# Altium schematic sheet KEA128.SchDoc(KEA128)
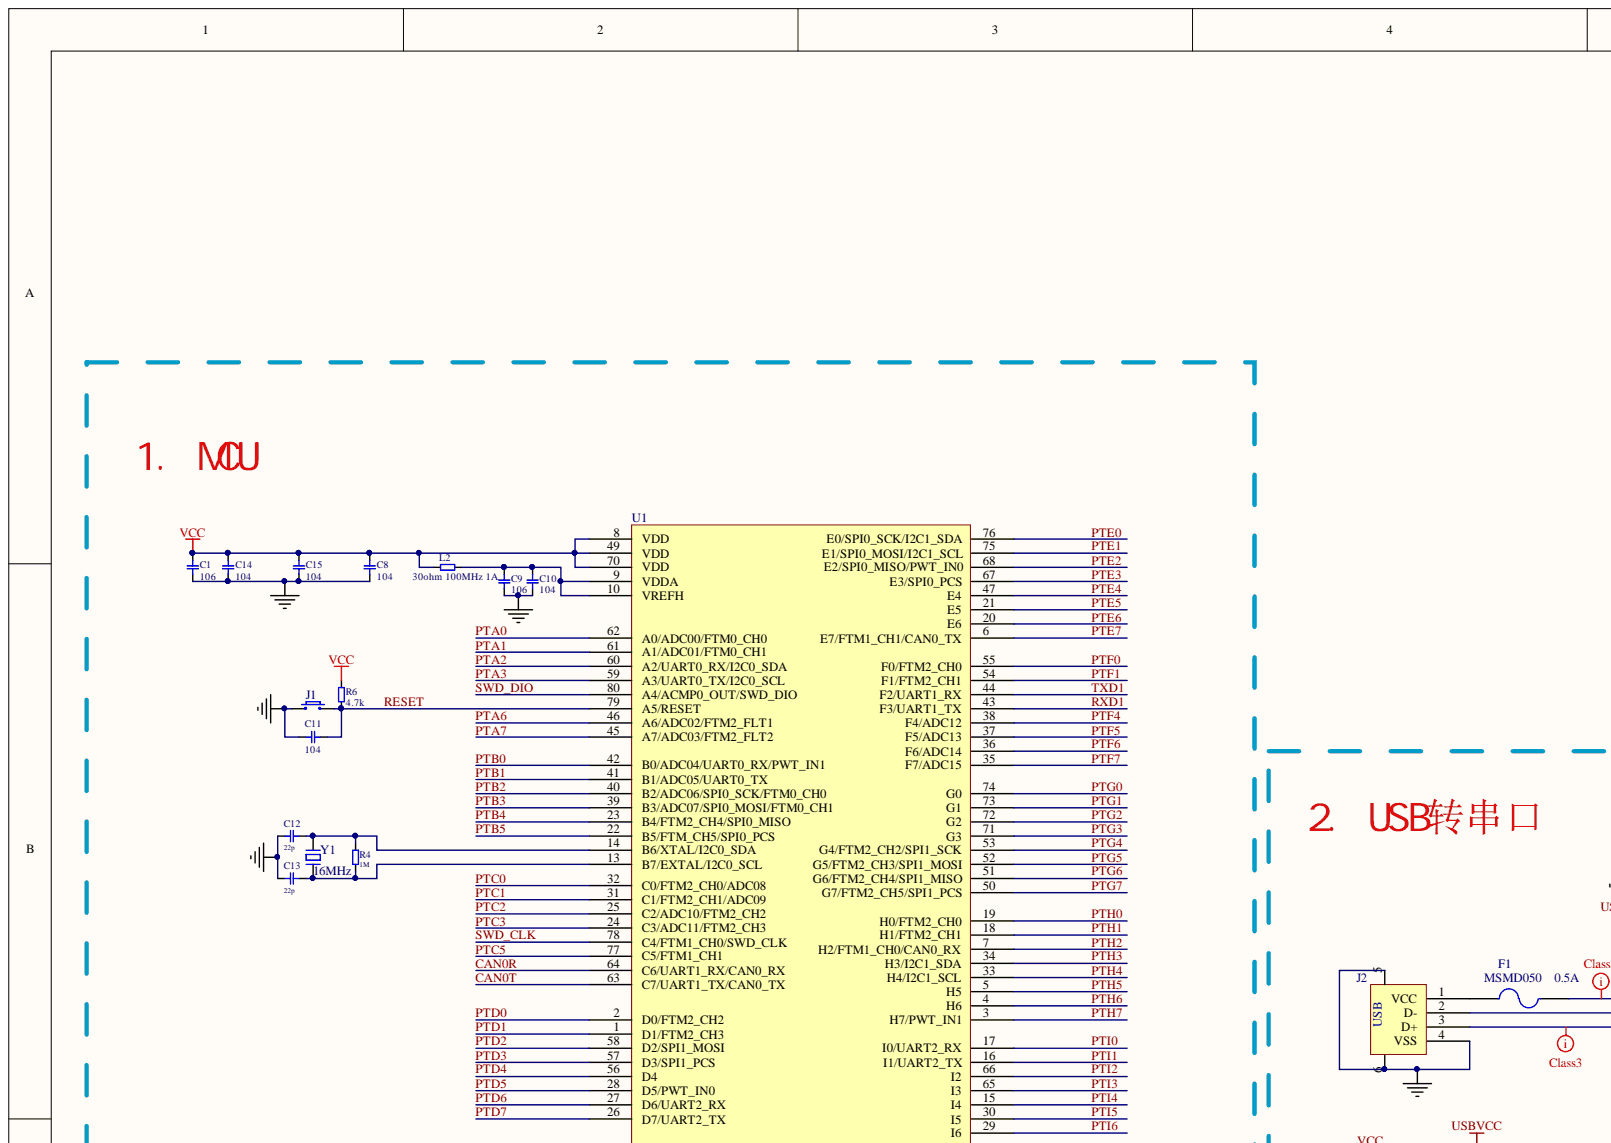
[diagram p0: KEA128.SchDoc, top left]
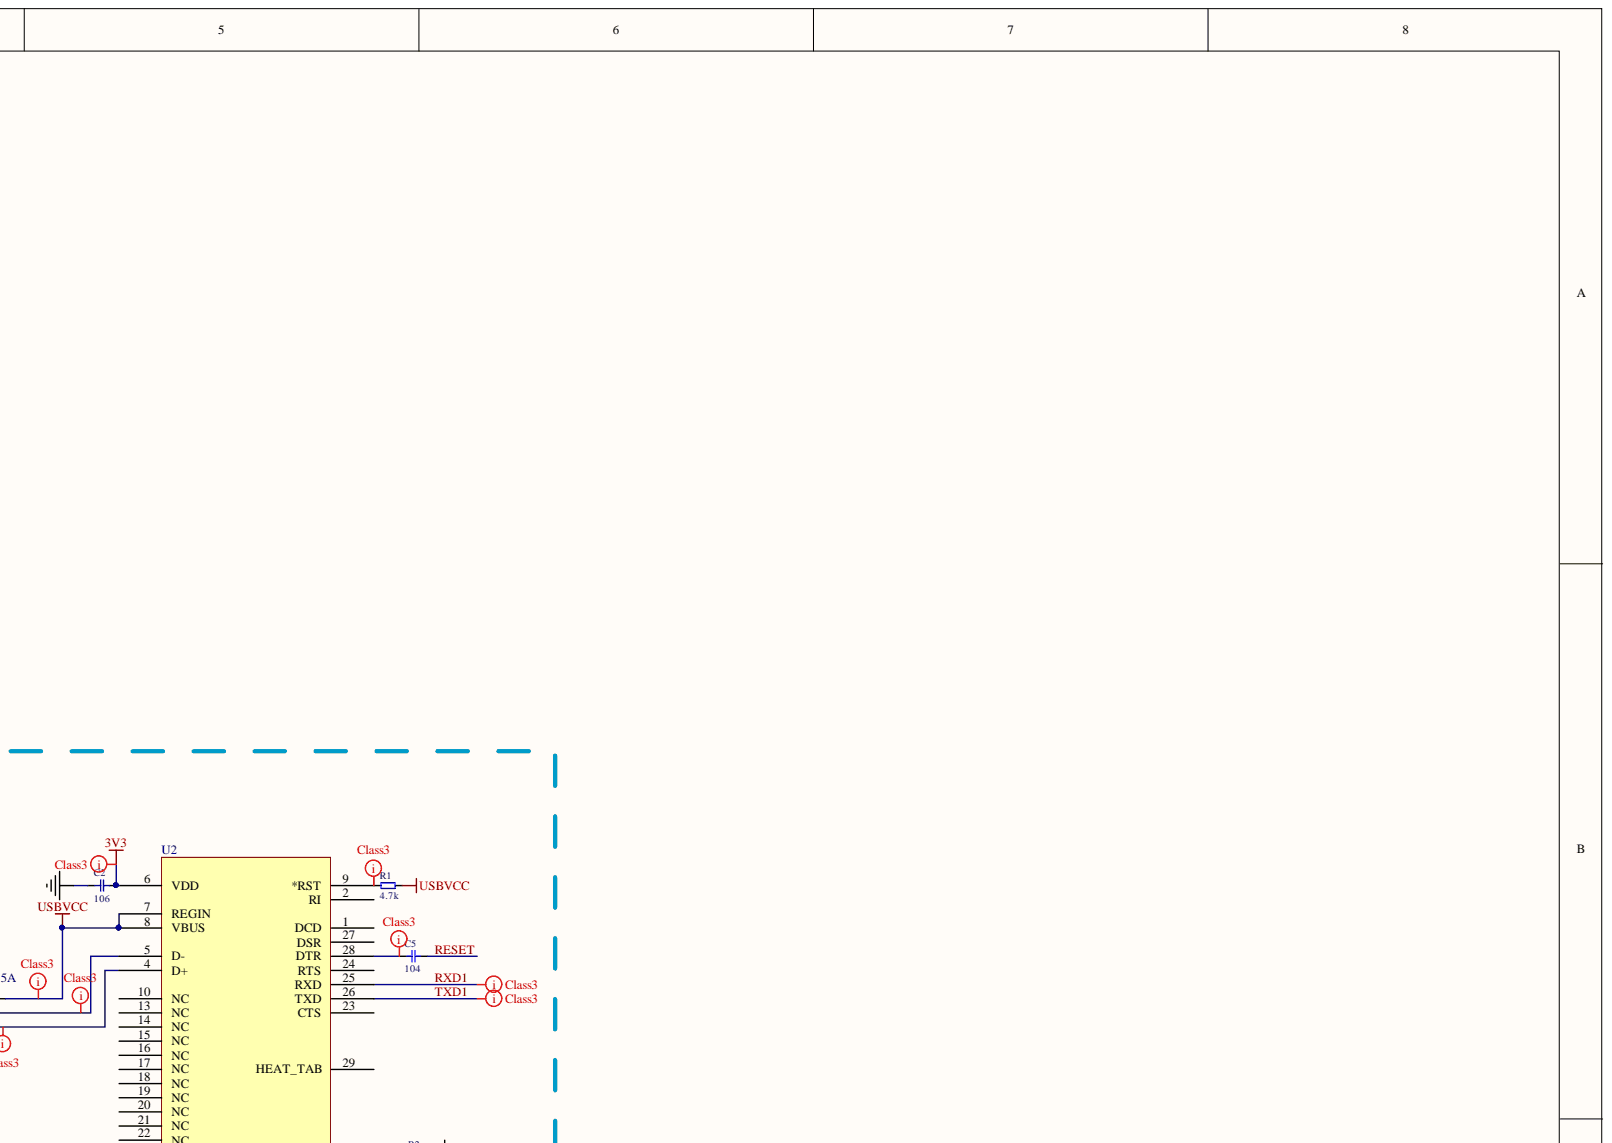
[diagram p1: KEA128.SchDoc, top right]
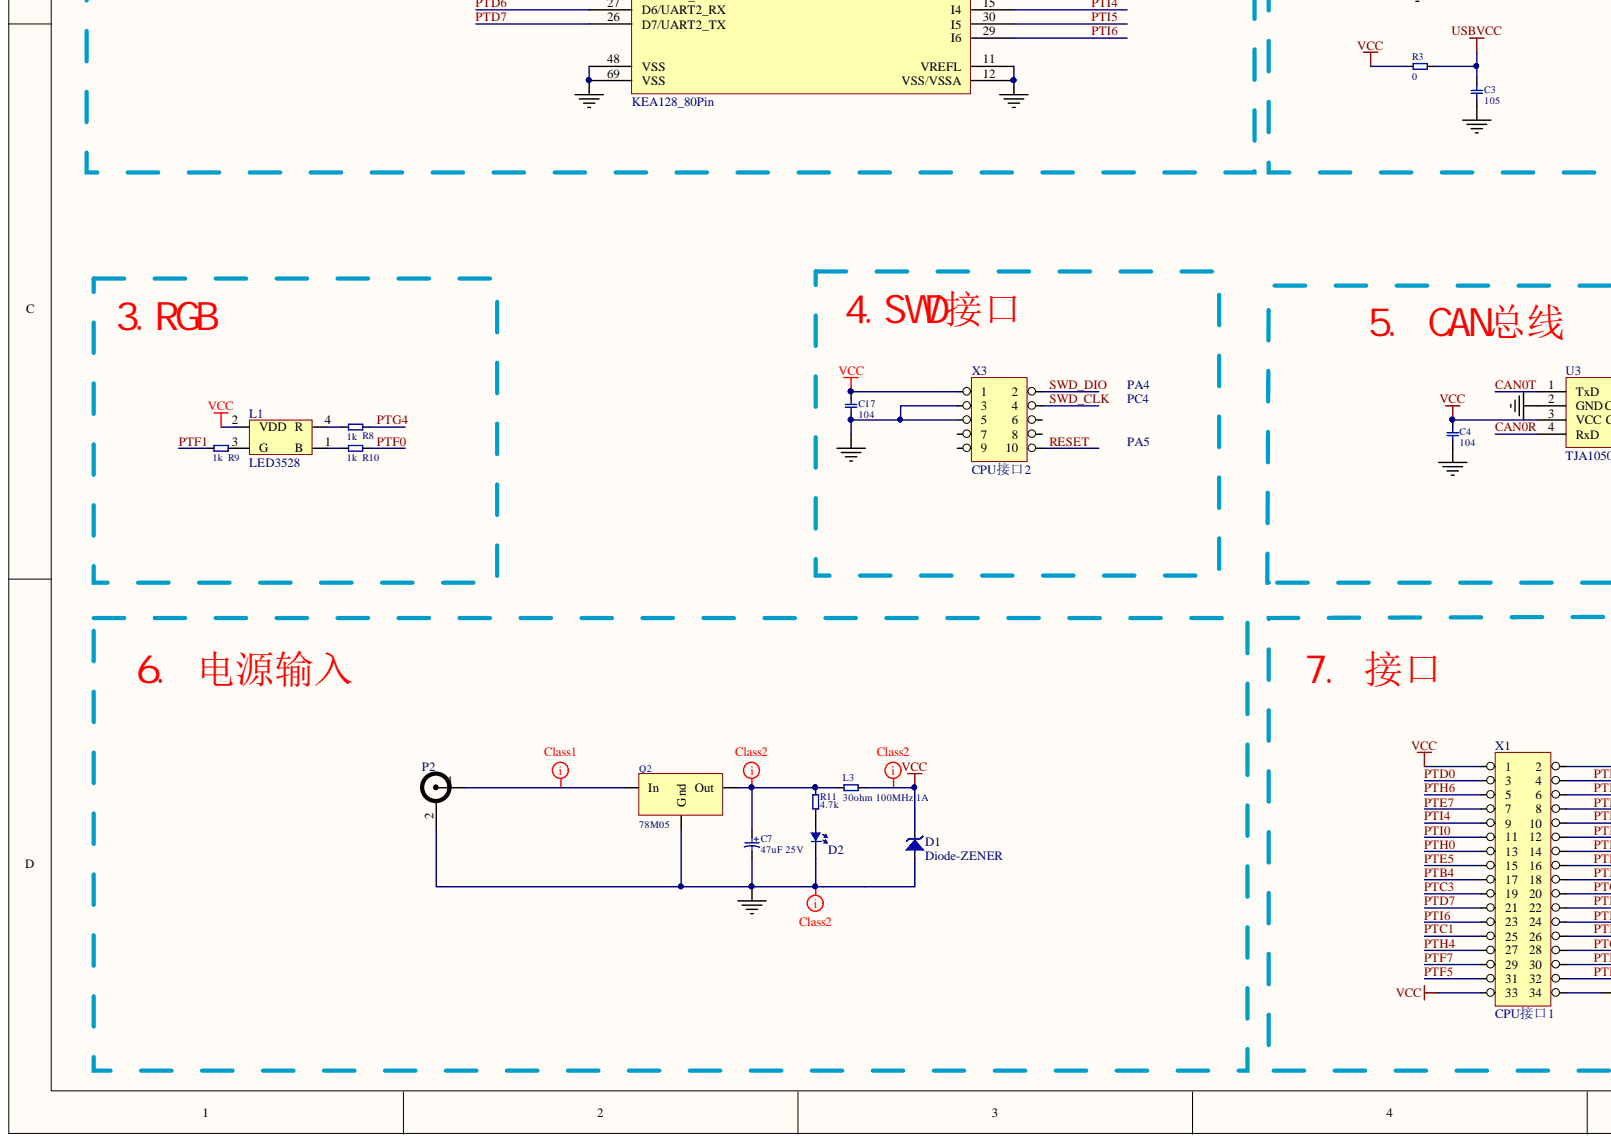
[diagram p2: KEA128.SchDoc, bottom left]
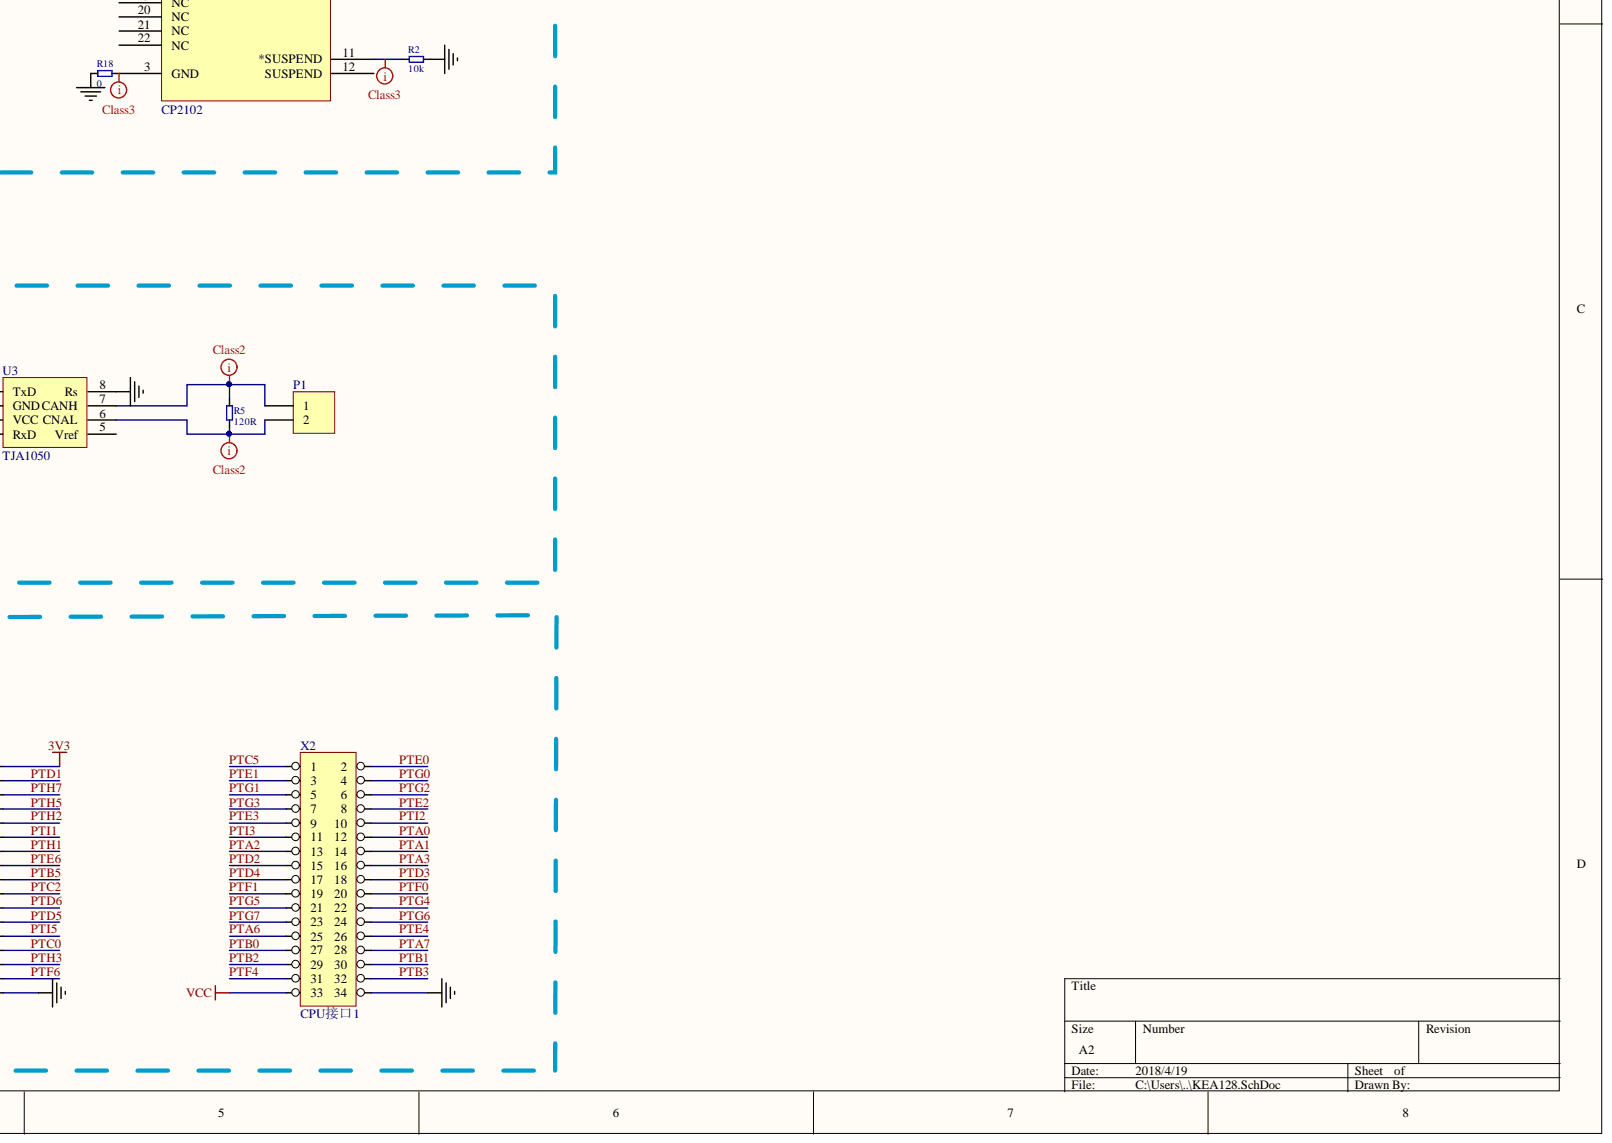
[diagram p3: KEA128.SchDoc, bottom right]

## Parts
C1  106  [0603]
C2  106  [0603]
C3  105  [0603]
C4  104  [0603]
C5  104  [0603]
C7  47uF 25V  [C-RB-S6]
C8  104  [0603]
C9  106  [0603]
C10  104  [0603]
C11  104  [0603]
C12  22p  [0603]
C13  22p  [0603]
C14  104  [0603]
C15  104  [0603]
C17  104  [0603]
D1  Diode-ZENER  [SOD-123_Zensor]
D2  Diode-PHOTO  [0805-DIODE]  (value not drawn)
F1  MSMD050  value 0.5A  [1206]
J1  SWITCHBUTTON  [SW_PB2_A1]  (value not drawn)
J2  USB  [USB_B1]
L1  LED3528  [RGB-3528]
L2  30ohm 100MHz 1A  [0603]
L3  30ohm 100MHz 1A  [0603]
P1  Header 2  [HEADER 2x1 C]  (value not drawn)
P2  BNC  [KLD-0202]  (value not drawn)
Q2  78M05  [TO-252AA-A]
R1  4.7k  [0603]
R2  10k  [0603]
R3  0  [0603]
R4  1M  [0603]
R5  120R  [0603]
R6  4.7k  [0603]
R8  1k  [0603]
R9  1k  [0603]
R10  1k  [0603]
R11  4.7k  [0603]
R18  0  [0603]
U1  KEA128_80Pin  [LQFP80]
U2  CP2102  [QFN28N5X5-0p5P]
U3  TJA1050  [SO-08]
X1  CPU接口1  [IDC-17X2]
X2  CPU接口1  [IDC-17X2]
X3  CPU接口2  [IDC-5X2]
Y1  16MHz  [CRYSTAL-5032]

## Nets
3V3: C2.1 U2.6(VDD) X1.2
GND: C1.1 C2.2 C3.2 C4.2 C7.2 C8.1 C9.1 C10.1 C11.1 C12.1 C13.1 C14.1 C15.1 C17.2 D1.A D2.2 J1.1 J2.4(VSS) J2.5 J2.6 P2.2 Q2.2 R2.2 R18.2 U1.11(VREFL) U1.12(VSS/VSSA) U1.48(VSS) U1.69(VSS) U3.2(GND) U3.8(Rs) X1.34 X2.34 X3.3 X3.5
USBVCC: C3.1 F1.2 R1.2 R3.2 U2.7(REGIN) U2.8(VBUS)
VCC: C1.2 C4.1 C8.2 C14.2 C15.2 C17.1 D1.K L1.2(VDD) L2.1 L3.1 R3.1 R6.1 U1.8(VDD) U1.49(VDD) U1.70(VDD) U3.3(VCC) X1.1 X1.33 X2.33 X3.1
CAN0R: U1.64(C6/UART1_RX/CAN0_RX) U3.4(RxD)
CAN0T: U1.63(C7/UART1_TX/CAN0_TX) U3.1(TxD)
PTA0: U1.62(A0/ADC00/FTM0_CH0) X2.12
PTA1: U1.61(A1/ADC01/FTM0_CH1) X2.14
PTA2: U1.60(A2/UART0_RX/I2C0_SDA) X2.13
PTA3: U1.59(A3/UART0_TX/I2C0_SCL) X2.16
PTA6: U1.46(A6/ADC02/FTM2_FLT1) X2.25
PTA7: U1.45(A7/ADC03/FTM2_FLT2) X2.28
PTB0: U1.42(B0/ADC04/UART0_RX/PWT_IN1) X2.27
PTB1: U1.41(B1/ADC05/UART0_TX) X2.30
PTB2: U1.40(B2/ADC06/SPI0_SCK/FTM0_CH0) X2.29
PTB3: U1.39(B3/ADC07/SPI0_MOSI/FTM0_CH1) X2.32
PTB4: U1.23(B4/FTM2_CH4/SPI0_MISO) X1.17
PTB5: U1.22(B5/FTM_CH5/SPI0_PCS) X1.18
PTC0: U1.32(C0/FTM2_CH0/ADC08) X1.28
PTC1: U1.31(C1/FTM2_CH1/ADC09) X1.25
PTC2: U1.25(C2/ADC10/FTM2_CH2) X1.20
PTC3: U1.24(C3/ADC11/FTM2_CH3) X1.19
PTC5: U1.77(C5/FTM1_CH1) X2.1
PTD0: U1.2(D0/FTM2_CH2) X1.3
PTD1: U1.1(D1/FTM2_CH3) X1.4
PTD2: U1.58(D2/SPI1_MOSI) X2.15
PTD3: U1.57(D3/SPI1_PCS) X2.18
PTD4: U1.56(D4) X2.17
PTD5: U1.28(D5/PWT_IN0) X1.24
PTD6: U1.27(D6/UART2_RX) X1.22
PTD7: U1.26(D7/UART2_TX) X1.21
PTE0: U1.76(E0/SPI0_SCK/I2C1_SDA) X2.2
PTE1: U1.75(E1/SPI0_MOSI/I2C1_SCL) X2.3
PTE2: U1.68(E2/SPI0_MISO/PWT_IN0) X2.8
PTE3: U1.67(E3/SPI0_PCS) X2.9
PTE4: U1.47(E4) X2.26
PTE5: U1.21(E5) X1.15
PTE6: U1.20(E6) X1.16
PTE7: U1.6(E7/FTM1_CH1/CAN0_TX) X1.7
PTF0: R10.2 U1.55(F0/FTM2_CH0) X2.20
PTF1: R9.2 U1.54(F1/FTM2_CH1) X2.19
PTF4: U1.38(F4/ADC12) X2.31
PTF5: U1.37(F5/ADC13) X1.31
PTF6: U1.36(F6/ADC14) X1.32
PTF7: U1.35(F7/ADC15) X1.29
PTG0: U1.74(G0) X2.4
PTG1: U1.73(G1) X2.5
PTG2: U1.72(G2) X2.6
PTG3: U1.71(G3) X2.7
PTG4: R8.2 U1.53(G4/FTM2_CH2/SPI1_SCK) X2.22
PTG5: U1.52(G5/FTM2_CH3/SPI1_MOSI) X2.21
PTG6: U1.51(G6/FTM2_CH4/SPI1_MISO) X2.24
PTG7: U1.50(G7/FTM2_CH5/SPI1_PCS) X2.23
PTH0: U1.19(H0/FTM2_CH0) X1.13
PTH1: U1.18(H1/FTM2_CH1) X1.14
PTH2: U1.7(H2/FTM1_CH0/CAN0_RX) X1.10
PTH3: U1.34(H3/I2C1_SDA) X1.30
PTH4: U1.33(H4/I2C1_SCL) X1.27
PTH5: U1.5(H5) X1.8
PTH6: U1.4(H6) X1.5
PTH7: U1.3(H7/PWT_IN1) X1.6
PTI0: U1.17(I0/UART2_RX) X1.11
PTI1: U1.16(I1/UART2_TX) X1.12
PTI2: U1.66(I2) X2.10
PTI3: U1.65(I3) X2.11
PTI4: U1.15(I4) X1.9
PTI5: U1.30(I5) X1.26
PTI6: U1.29(I6) X1.23
RESET: C5.2 C11.2 J1.2 R6.2 U1.79(A5/RESET) X3.10
RXD1: U1.43(F3/UART1_TX) U2.25(RXD)
SWD_CLK: U1.78(C4/FTM1_CH0/SWD_CLK) X3.4
SWD_DIO: U1.80(A4/ACMP0_OUT/SWD_DIO) X3.2
TXD1: U1.44(F2/UART1_RX) U2.26(TXD)
NetC5_1: C5.1 U2.28(DTR)
NetC7_1: C7.1 L3.2 Q2.3 R11.2
NetC9_2: C9.2 C10.2 L2.2 U1.9(VDDA) U1.10(VREFH)
NetC12_2: C12.2 R4.1 U1.14(B6/XTAL/I2C0_SDA) Y1.1
NetC13_2: C13.2 R4.2 U1.13(B7/EXTAL/I2C0_SCL) Y1.2
NetD2_1: D2.1 R11.1
NetF1_1: F1.1 J2.1(VCC)
NetJ2_2: J2.2(D-) U2.5(D-)
NetJ2_3: J2.3(D+) U2.4(D+)
NetL1_1: L1.1(B) R10.1
NetL1_3: L1.3(G) R9.1
NetL1_4: L1.4(R) R8.1
NetP1_1: P1.1 R5.2 U3.7(CANH)
NetP1_2: P1.2 R5.1 U3.6(CNAL)
NetP2_1: P2.1 Q2.1
NetR1_1: R1.1 U2.9(*RST)
NetR2_1: R2.1 U2.11(*SUSPEND)
NetR18_1: R18.1 U2.3(GND)

Not connected: U2 1(DCD) 2(RI) 10(NC) 12(SUSPEND) 13(NC) 14(NC) 15(NC) 16(NC) 17(NC) 18(NC) 19(NC) 20(NC) 21(NC) 22(NC) 23(CTS) 24(RTS) 27(DSR) 29(HEAT_TAB); U3 5(Vref); X3 6 7 8 9

## Library data of the parts
C1-C5 C8-C15 C17 = CAP: Capacitor; Component Kind: Standard
  C1 C8-C11 C14 C15 C17: Library Name: MySchlib.SchLib
  C2-C5 C12 C13: Library Name: MyIntegrated_Library.IntLib
C7 = CAPACITOR POL_1: Capacitor; Component Kind: Standard; Library Name: MyIntegrated_Library.IntLib
D1 = Diode-ZENER: Zener Diode, 1N5238，还包括瞬态抑制二极管SMB系列; Component Kind: Standard; Library Name: MySchlib.SchLib
D2 = Diode-PHOTO: 1N4148-1805-DIODE; Component Kind: Standard; Library Name: MyIntegrated_Library.IntLib
F1 = Fuse 2: 保险丝; Component Kind: Standard; LatestRevisionDate: 17-Jul-2002; LatestRevisionNote: Re-released for DXP Platform.; Library Name: Miscellaneous Devices.IntLib; PackageReference: PIN-W2/E2.8; Published: 8-Jun-2000; Publisher: Altium Limited
J1 = SWITCHBUTTON: Button; Component Kind: Standard; Library Name: MyIntegrated_Library.IntLib
J2 = USB: USB接口; Component Kind: Standard
L2 L3 R4-R6 R8-R11 = RES2: Component Kind: Standard
  L2 L3 R4 R5 R8-R11: Library Name: MyIntegrated_Library.IntLib
  R6: Library Name: MySchlib.SchLib
P1 = Header 2: 接插件; Component Kind: Standard; LatestRevisionDate: 17-Jul-2002; LatestRevisionNote: Re-released for DXP Platform.; Library Name: Miscellaneous Connectors.IntLib; Publisher: Altium Limited
P2 = BNC: BNC Socket; Component Kind: Standard; Library Name: MyIntegrated_Library.IntLib
Q2 = ThreeTerminal-78M05: Three-Terminal Negative Voltage Regulator; Component Kind: Standard; Library Name: MyIntegrated_Library.IntLib
R1-R3 R18 = RES2: value: 120; Component Kind: Standard; Library Name: MyIntegrated_Library.IntLib
U1 = KEA128_80Pin: KEA128; Component Kind: Standard; Library Name: MySchlib.SchLib
U2 = CP2102: Imported; PART DESC: SINGLE-CHIP USB TO UART BRIDGE, QFN28, RoHS.; MFG #1: SILICON LABS; Component Kind: Standard; Library Name: MyIntegrated_Library.IntLib; PART NUMBER: CP2102; RefDes: RefDes; Type: DEV; Value: Value
U3 = TJA1040: CAN; Component Kind: Standard; Library Name: MyIntegrated_Library.IntLib
X1 X2 = HEADER 17X2: 40 Pin Header; Component Kind: Standard; Library Name: MyIntegrated_Library.IntLib
X3 = HEADER 5X2: 10 Pin Header; Component Kind: Standard; Library Name: LXH_Integrated_Library2018.IntLib
Y1 = CRYSTAL: Component Kind: Standard; Library Name: MyIntegrated_Library.IntLib
L1 = LED3528: Component Kind: Standard; Library Name: MyIntegrated_Library.IntLib
